# Altium schematic sheet bldc_drive_driver.SchDoc
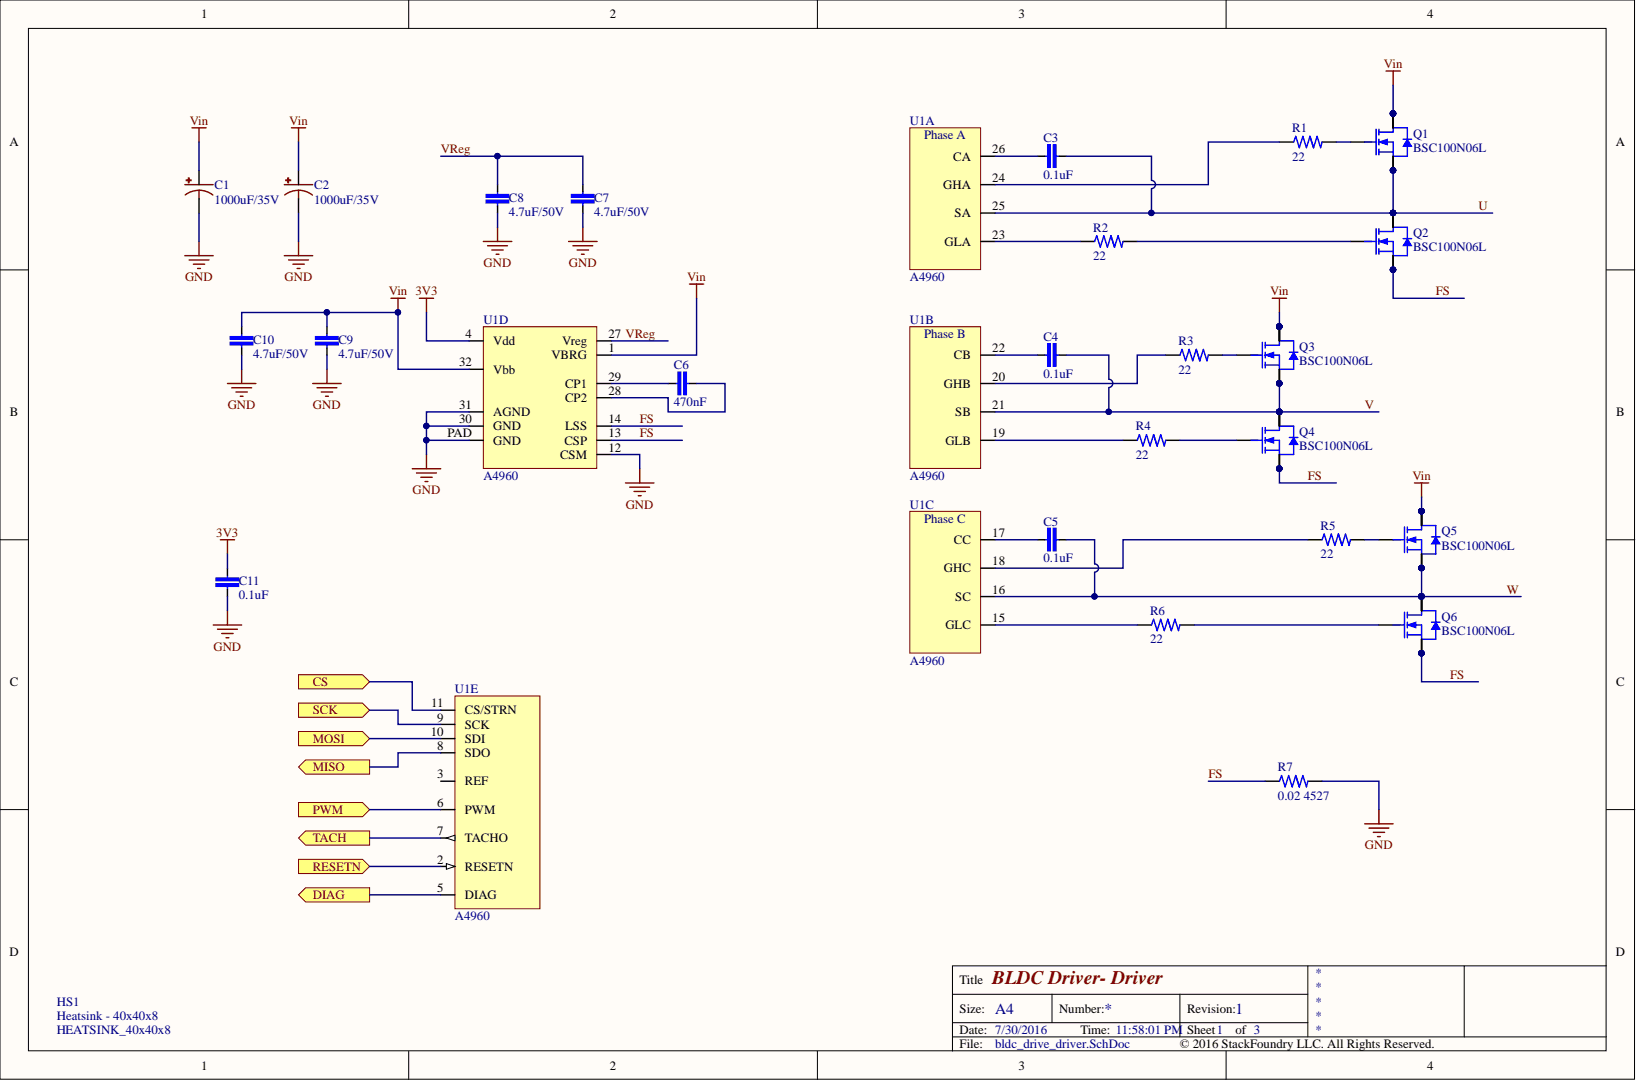
[diagram p0: bldc_drive_driver.SchDoc]

## Parts
C1  1000uF/35V  [C_5x10x32mm]
C2  1000uF/35V  [C_5x10x32mm]
C3  0.1uF  [C0603]
C4  0.1uF  [C0603]
C5  0.1uF  [C0603]
C6  470nF  [C0603]
C7  4.7uF/50V  [C1210]
C8  4.7uF/50V  [C1210]
C9  4.7uF/50V  [C1210]
C10  4.7uF/50V  [C1210]
C11  0.1uF  [C0603]
HS1  HEATSINK_40x40x8  [Heatsink - 40x40x8]
Q1  BSC100N06L  [PG-TDSON-8]
Q2  BSC100N06L  [PG-TDSON-8]
Q3  BSC100N06L  [PG-TDSON-8]
Q4  BSC100N06L  [PG-TDSON-8]
Q5  BSC100N06L  [PG-TDSON-8]
Q6  BSC100N06L  [PG-TDSON-8]
R1  22  [R0603]
R2  22  [R0603]
R3  22  [R0603]
R4  22  [R0603]
R5  22  [R0603]
R6  22  [R0603]
R7  0.02 4527  [R4527]
U1  A4960  [LQFP32-EP]  (parts A,B,C,D,E)

## Ports
CS, DIAG, MISO, MOSI, PWM, RESETN, SCK, TACH

## Nets
3V3: C11.2 U1.4(Vdd)
GND: C1.- C2.- C7.1 C8.2 C9.2 C10.2 C11.1 R7.2 U1.12(CSM) U1.30(GND) U1.31(AGND) U1.PAD(GND)
VIN: C1.+ C2.+ C9.1 C10.1 Q1.5 Q1.6 Q1.7 Q1.8 Q1.PAD Q3.5 Q3.6 Q3.7 Q3.8 Q3.PAD Q5.5 Q5.6 Q5.7 Q5.8 Q5.PAD U1.1(VBRG) U1.32(Vbb)
CS: U1.11(CS/STRN) ; port CS
DIAG: U1.5(DIAG) ; port DIAG
FS: Q2.1 Q2.2 Q2.3 Q4.1 Q4.2 Q4.3 Q6.1 Q6.2 Q6.3 R7.1 U1.13(CSP) U1.14(LSS)
MISO: U1.8(SDO) ; port MISO
MOSI: U1.10(SDI) ; port MOSI
PWM: U1.6(PWM) ; port PWM
RESETN: U1.2(RESETN) ; port RESETN
SCK: U1.9(SCK) ; port SCK
TACH: U1.7(TACHO) ; port TACH
U: C3.2 Q1.1 Q1.2 Q1.3 Q2.5 Q2.6 Q2.7 Q2.8 Q2.PAD U1.25(SA)
V: C4.2 Q3.1 Q3.2 Q3.3 Q4.5 Q4.6 Q4.7 Q4.8 Q4.PAD U1.21(SB)
VREG: C7.2 C8.1 U1.27(Vreg)
W: C5.2 Q5.1 Q5.2 Q5.3 Q6.5 Q6.6 Q6.7 Q6.8 Q6.PAD U1.16(SC)
NetC3_1: C3.1 U1.26(CA)
NetC4_1: C4.1 U1.22(CB)
NetC5_1: C5.1 U1.17(CC)
NetC6_1: C6.1 U1.29(CP1)
NetC6_2: C6.2 U1.28(CP2)
NetQ1_4: Q1.4 R1.2
NetQ2_4: Q2.4 R2.2
NetQ3_4: Q3.4 R3.2
NetQ4_4: Q4.4 R4.2
NetQ5_4: Q5.4 R5.2
NetQ6_4: Q6.4 R6.2
NetR1_1: R1.1 U1.24(GHA)
NetR2_1: R2.1 U1.23(GLA)
NetR3_1: R3.1 U1.20(GHB)
NetR4_1: R4.1 U1.19(GLB)
NetR5_1: R5.1 U1.18(GHC)
NetR6_1: R6.1 U1.15(GLC)

Not connected: U1 3(REF)

## Library data of the parts
C1 C2 = CPOL-US: Component Kind: Standard; Library Name: rcl.IntLib
C3-C11 = C-EU: Component Kind: Standard; Library Name: rcl.IntLib
Q1-Q6 = NFET_3S_5D: Component Kind: Standard; Library Name: general.IntLib
R1-R7 = R-US: Component Kind: Standard; Library Name: rcl.IntLib
U1 = A4960-A: Component Kind: Standard; Library Name: general.IntLib
HS1 = HEATSINK_40x40x8: Component Kind: Standard; Library Name: mechanical.IntLib
